# Altium schematic sheet Sonic.SchDoc
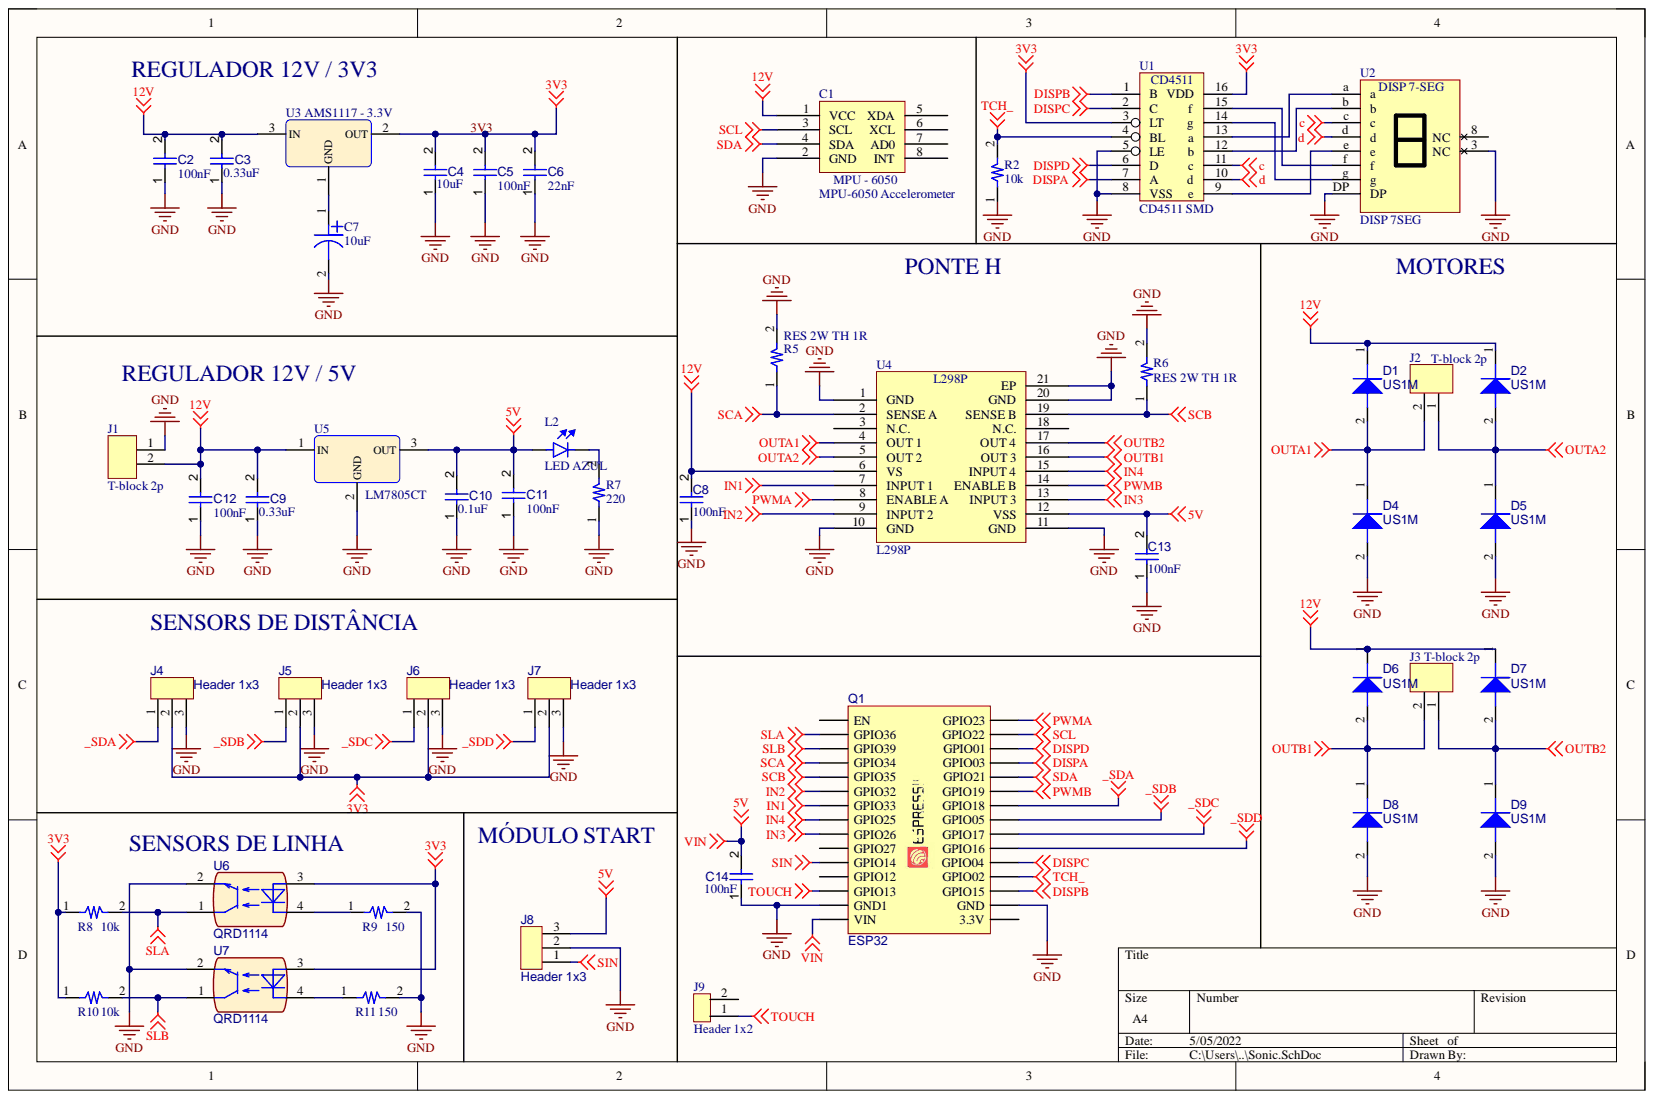
[diagram p0: Sonic.SchDoc]

## Parts
C1  MPU-6050 Accelerometer  [MPU-6050 Accelerometer-Footprint-1]
C2  value 100nF  [CAPC2013X95X50LL10T25]  (hidden comment: Capacitor 100 nF +/-10% 50 V 0805)
C3  value 0.33uF  [CAPC2013X95X50LL10T25]  (hidden comment: Capacitor 100 nF +/-10% 50 V 0805)
C4  value 10uF  [CAPC2013X95X50LL10T25]  (hidden comment: Capacitor 100 nF +/-10% 50 V 0805)
C5  value 100nF  [CAPC2013X95X50LL10T25]  (hidden comment: Capacitor 100 nF +/-10% 50 V 0805)
C6  value 22nF  [CAPC2013X95X50LL10T25]  (hidden comment: Capacitor 100 nF +/-10% 50 V 0805)
C7  10uF  [ElerolitcCap 35V]
C8  value 100nF  [CAPC2013X95X50LL10T25]  (hidden comment: Capacitor 100 nF +/-10% 50 V 0805)
C9  value 0.33uF  [CAPC2013X95X50LL10T25]  (hidden comment: Capacitor 100 nF +/-10% 50 V 0805)
C10  value 0.1uF  [CAPC2013X95X50LL10T25]  (hidden comment: Capacitor 100 nF +/-10% 50 V 0805)
C11  value 100nF  [CAPC2013X95X50LL10T25]  (hidden comment: Capacitor 100 nF +/-10% 50 V 0805)
C12  value 100nF  [CAPC2013X95X50LL10T25]  (hidden comment: Capacitor 100 nF +/-10% 50 V 0805)
C13  value 100nF  [CAPC2013X95X50LL10T25]  (hidden comment: Capacitor 100 nF +/-10% 50 V 0805)
C14  value 100nF  [CAPC2013X95X50LL10T25]  (hidden comment: Capacitor 100 nF +/-10% 50 V 0805)
D1  US1M  [DO-214AC]
D2  US1M  [DO-214AC]
D4  US1M  [DO-214AC]
D5  US1M  [DO-214AC]
D6  US1M  [DO-214AC]
D7  US1M  [DO-214AC]
D8  US1M  [DO-214AC]
D9  US1M  [DO-214AC]
J1  T-block 2p  [T-block 2p]
J2  T-block 2p  [T-block 2p]
J3  T-block 2p  [T-block 2p]
J4  Header 1x3  [Header 1x3]
J5  Header 1x3  [Header 1x3]
J6  Header 1x3  [Header 1x3]
J7  Header 1x3  [Header 1x3]
J8  Header 1x3  [Header 1x3]
J9  Header 1x2  [Header 1x2]
L2  LED AZUL  [LED 5mm-Footprint-1]
Q1  ESP32  [DOTI ESP32]
R2  10k  [47K RES SMD]
R5  RES 2W TH 1R  [RES 2W TH]
R6  RES 2W TH 1R  [RES 2W TH]
R7  220  [47K RES SMD]
R8  10k  [47K RES SMD]
R9  150  [47K RES SMD]
R10  10k  [47K RES SMD]
R11  150  [47K RES SMD]
U1  CD4511 SMD  [CD4511 SMD]
U2  DISP 7SEG  [DISP 7SEG]
U3  AMS1117 - 3.3V  [AMS1117]
U4  L298P  [L298P]
U5  LM7805CT  [L78XX FAMILY]
U6  QRD1114  [QRD1114]
U7  QRD1114  [QRD1114]

## Nets
5V: C10.2 C11.2 C13.2 C14.2 J8.3 L2.1 Q1.1(VIN) U4.12(VSS) U5.3
12V: C1.1(VCC) C2.2 C3.2 C8.2 C9.2 C12.2 D1.1 D2.1 D6.1 D7.1 J1.2 U3.3 U4.6(VS) U5.1
_SDA: J4.1 Q1.22(GPIO18)
_SDB: J5.1 Q1.23(GPIO05)
_SDC: J6.1 Q1.24(GPIO17)
_SDD: J7.1 Q1.25(GPIO16)
c: U1.11(c) U2.c
d: U1.10(d) U2.d
DISPA: Q1.19(GPIO03) U1.7(A)
DISPB: Q1.28(GPIO15) U1.1(B)
DISPC: Q1.26(GPIO04) U1.2(C)
DISPD: Q1.18(GPIO01) U1.6(D)
GND: C1.2(GND) C2.1 C3.1 C4.1 C5.1 C6.1 C7.2 C8.1 C9.1 C10.1 C11.1 C12.1 C13.1 C14.1 D4.2 D5.2 D8.2 D9.2 J1.1 J4.3 J5.3 J6.3 J7.3 J8.2 Q1.2(GND1) Q1.29(GND) R2.1 R5.2 R6.2 R7.1 R9.2 R11.2 U1.5(LE) U1.8(VSS) U2.3(NC) U2.DP U4.1(GND) U4.10(GND) U4.11(GND) U4.20(GND) U4.21(EP) U5.2 U6.2 U7.2
IN1: Q1.9(GPIO33) U4.7(INPUT_1)
IN2: Q1.10(GPIO32) U4.9(INPUT_2)
IN3: Q1.7(GPIO26) U4.13(INPUT_3)
IN4: Q1.8(GPIO25) U4.15(INPUT_4)
OUTA1: D1.2 D4.1 J2.2 U4.4(OUT_1)
OUTA2: D2.2 D5.1 J2.1 U4.5(OUT_2)
OUTB1: D6.2 D8.1 J3.2 U4.16(OUT_3)
OUTB2: D7.2 D9.1 J3.1 U4.17(OUT_4)
PWMA: Q1.16(GPIO23) U4.8(ENABLE_A)
PWMB: Q1.21(GPIO19) U4.14(ENABLE_B)
SCA: Q1.12(GPIO34) R5.1 U4.2(SENSE_A)
SCB: Q1.11(GPIO35) R6.1 U4.19(SENSE_B)
SCL: C1.3(SCL) Q1.17(GPIO22)
SDA: C1.4(SDA) Q1.20(GPIO21)
SIN: J8.1 Q1.5(GPIO14)
SLA: Q1.14(GPIO36) R8.2 U6.1
SLB: Q1.13(GPIO39) R10.2 U7.1
TCH_: Q1.27(GPIO02) R2.2 U1.4(BL)
TOUCH: J9.1 Q1.3(GPIO13)
3V3: C4.2 C5.2 C6.2 J4.2 J5.2 J6.2 J7.2 R8.1 R10.1 U1.3(LT) U1.16(VDD) U3.2 U6.3 U7.3
NetC7_1: C7.1 U3.1
NetL2_2: L2.2 R7.2
NetR9_1: R9.1 U6.4
NetR11_1: R11.1 U7.4
NetU1_9: U1.9(e) U2.e
NetU1_12: U1.12(b) U2.b
NetU1_13: U1.13(a) U2.a
NetU1_14: U1.14(g) U2.g
NetU1_15: U1.15(f) U2.f

Not connected: C1 5(XDA) 6(XCL) 7(AD0) 8(INT); J9 2; Q1 4(GPIO12) 6(GPIO27) 15(EN) 30(3.3V); U2 8(NC); U4 3(N.C.) 18(N.C.)

## Library data of the parts
C1 = CMP-00002-1: Accelerometer, Gyroscope, 3 axis sensor; Component Kind: Standard; Library Name: Sistema_Elevador_ElevaUV.IntLib
C2-C6 C8-C14 = CMP-001-00018-5: Chip Capacitor, 100 nF, +/- 10%, 50 V, 0805 (2012 Metric); Capacitor Type: Ceramic; Voltage Rating: 50V; Case/Package: 0805; Component Kind: Standard; Max Operating Temperature: 125°C; Min Operating Temperature: -55°C; Mounting Technology: SM; Pins: 2; RoHS Compliant: True; Tolerance: 10%; Library Name: Universidade Estadual Paulista - Unesp
C7 = 10uF SMD Eletrolitc Cap: CAP ALUM 10UF 20% 35V SMD; Manufacturer: Panasonic; Manufacturer Part Number: EEEFK1V100UR; ESR (Equivalent Series Resistance): 1.35R; Leakage Current: 3uA; Life (Hours): 2000Hour; Material: Aluminium; Polarity: Polar; Ripple Current: 90mA; Capacitance: 10uF; Case/Package: Radial; Category: Aluminum Electrolytic Capacitors; Component Kind: Standard; ComponentLink1Description: https://datasheet.ciiva.com/11777/0900766b80e4e8b0-11777251.pdf?src-supplier=RSComponents; ComponentLink1URL: https://datasheet.ciiva.com/11777/0900766b80e4e8b0-11777251.pdf?src-supplier=RSComponents; ComponentLink2Description: https://datasheet.ciiva.com/29313/443474-da-01-en-smd-elko-vfk-b-10-uf-35v-29313572.pdf?src-supplier=Conrad; ComponentLink2URL: https://datasheet.ciiva.com/29313/443474-da-01-en-smd-elko-vfk-b-10-uf-35v-29313572.pdf?src-supplier=Conrad; ComponentLink3Description: https://datasheet.ciiva.com/3773/41790907s9016630n1386-3773674.pdf?src-supplier=Arrow+Electronics; ComponentLink3URL: https://datasheet.ciiva.com/3773/41790907s9016630n1386-3773674.pdf?src-supplier=Arrow+Electronics; ComponentLink4Description: https://datasheet.ciiva.com/11673/aba0000ce120-11673880.pdf?src-supplier=Avnet; ComponentLink4URL: https://datasheet.ciiva.com/11673/aba0000ce120-11673880.pdf?src-supplier=Avnet; ComponentLink5Description: https://datasheet.ciiva.com/2983/aba0000ce120-2983969.pdf?src-supplier=Avnet; ComponentLink5URL: https://datasheet.ciiva.com/2983/aba0000ce120-2983969.pdf?src-supplier=Avnet; ComponentLink6Description: https://datasheet.ciiva.com/43463/58258-43463543.pdf?src-supplier=Farnell; ComponentLink6URL: https://datasheet.ciiva.com/43463/58258-43463543.pdf?src-supplier=Farnell; ComponentLink7Description: https://datasheet.ciiva.com/43485/1676921-43485502.pdf?src-supplier=Farnell; ComponentLink7URL: https://datasheet.ciiva.com/43485/1676921-43485502.pdf?src-supplier=Farnell; ComponentLink8Description: https://datasheet.ciiva.com/30131/aba0000ce120-30131022.pdf?src-supplier=Onlinecomponents.com; ComponentLink8URL: https://datasheet.ciiva.com/30131/aba0000ce120-30131022.pdf?src-supplier=Onlinecomponents.com; ComponentLink9Description: https://datasheet.ciiva.com/48524/fk-eng-tds-48524122.pdf?src-supplier=Distrelec; ComponentLink9URL: https://datasheet.ciiva.com/48524/fk-eng-tds-48524122.pdf?src-supplier=Distrelec; ComponentLink10Description: https://datasheet.ciiva.com/46437/1676921-46437695.pdf?src-supplier=Element14; ComponentLink10URL: https://datasheet.ciiva.com/46437/1676921-46437695.pdf?src-supplier=Element14; ComponentLink11Description: https://datasheet.ciiva.com/17356/aba0000ce120-17356246.pdf?src-supplier=Avnet+Europe; ComponentLink11URL: https://datasheet.ciiva.com/17356/aba0000ce120-17356246.pdf?src-supplier=Avnet+Europe; Construction: Cylindrical; Contact Plating: Tin; Diameter: 4mm; Height: 5.8mm; Height - Seated (Max): 0.24inch; Impedance: 1.35R; Lead Free: Lead Free; Length: 5.8mm; Max Operating Temperature: 105°C; Min Operating Temperature: -55°C; Mount: Surface Mount,PCB; Number of Pins: 2; Package Quantity: 1; Packaging: Tape and Reel; Pricing 1: 1=0,35000, 10=0,24000, 50=0,16820, 100=0,14420, 500=0,10816, 1000=0,09133 (USD); Radiation Hardening: No; REACH SVHC: No SVHC; Ripple Current (AC): 90mA; RoHS: Yes; RoHS Compliant: Yes; Series: FK; Stock 1: 7253; Supplier 1: Digi-Key; Supplier Part Number 1: PCE3834CT-ND; Termination: SMD/SMT; Tolerance: 20%; Voltage: 35V; Voltage Rating: 35V; Voltage Rating (DC): 35V; Width: 5.5mm; Library Name: Extrusora_Schlib.SchLib
D1 D2 D4-D9 = US1M: Rectifier Diode, 1 Element, 1A, 1000V V(RRM), Silicon, DO-214AC; Manufacturer: ON Semiconductor / Fairchild; Manufacturer Part Number: S1M; Capacitance: 12pF; Element Configuration: Single; Forward Voltage: 1V; Max Forward Surge Current (Ifsm): 30A; Max Repetitive Reverse Voltage (Vrrm): 1kV; Max Reverse Current: 1uA; Max Surge Current: 40A; Polarity: Standard; Power Dissipation: 1.4W; Recovery Time: 1800ns; Reverse Recovery Time: 1.8us; Average Rectified Current: 1A; Case/Package: DO-214AC; Category: Rectifier Diodes; Component Kind: Standard; ComponentLink1Description: https://datasheet.ciiva.com/4452/s1m-191340-4452980.pdf?src-supplier=Mouser; ComponentLink1URL: https://datasheet.ciiva.com/4452/s1m-191340-4452980.pdf?src-supplier=Mouser; ComponentLink2Description: https://datasheet.ciiva.com/27051/1072719-27051364.pdf?src-supplier=Jameco; ComponentLink2URL: https://datasheet.ciiva.com/27051/1072719-27051364.pdf?src-supplier=Jameco; ComponentLink3Description: https://datasheet.ciiva.com/910/s1a-910496.pdf?src-supplier=Digi-Key; ComponentLink3URL: https://datasheet.ciiva.com/910/s1a-910496.pdf?src-supplier=Digi-Key; ComponentLink4Description: https://datasheet.ciiva.com/5739/1766308-5739339.pdf?src-supplier=Farnell; ComponentLink4URL: https://datasheet.ciiva.com/5739/1766308-5739339.pdf?src-supplier=Farnell; ComponentLink5Description: https://datasheet.ciiva.com/8303/s1k-8303577.pdf?src-supplier=Avnet; ComponentLink5URL: https://datasheet.ciiva.com/8303/s1k-8303577.pdf?src-supplier=Avnet; ComponentLink6Description: https://datasheet.ciiva.com/7965/1766308-7965189.pdf?src-supplier=Element14; ComponentLink6URL: https://datasheet.ciiva.com/7965/1766308-7965189.pdf?src-supplier=Element14; ComponentLink7Description: https://datasheet.ciiva.com/7965/96437-7965306.pdf?src-supplier=Element14; ComponentLink7URL: https://datasheet.ciiva.com/7965/96437-7965306.pdf?src-supplier=Element14; ComponentLink8Description: https://datasheet.ciiva.com/7965/1766308-7965307.pdf?src-supplier=Element14; ComponentLink8URL: https://datasheet.ciiva.com/7965/1766308-7965307.pdf?src-supplier=Element14; ComponentLink9Description: https://datasheet.ciiva.com/22651/1766308-22651974.pdf?src-supplier=Element14; ComponentLink9URL: https://datasheet.ciiva.com/22651/1766308-22651974.pdf?src-supplier=Element14; Contact Plating: Tin,Matte; Current: 1A; Current Rating: 1A; Forward Current: 1A; Height: 2.2mm; Lead Free: Lead Free; Length: 4.75mm; Max Operating Temperature: 150°C; Max Reverse Voltage (DC): 1kV; Min Operating Temperature: -55°C; Mount: Surface Mount; Number of Pins: 2; Output Current: 1A; Package Quantity: 1; Packaging: Tape and Reel; Peak Non-Repetitive Surge Current: 30A; Pricing 1: 1=0,44000, 10=0,32400, 100=0,18340, 500=0,12148, 1000=0,09313, 2000=0,08099 (USD); Radiation Hardening: No; REACH SVHC: No SVHC; Reverse Voltage: 1kV; RoHS: No; RoHS Compliant: No; Stock 1: 1057473; Supplier 1: Digi-Key; Supplier Part Number 1: S1MFSCT-ND; Termination: SMD/SMT; Voltage: 1kV; Voltage Rating (DC): 1kV; Width: 5.28mm; Library Name: Extrusora_Schlib.SchLib
J1-J3 = T-block 2p: WURTH ELEKTRONIK - 691213710002 - Wire-To-Board Terminal Block, 2, 300 V, 10 A, 26 AWG, 16 AWG, 1.5 mm RoHS Compliant: Yes; Manufacturer: Wurth Electronics; Current: 10A; Number of Contacts: 2; Number of Levels: 1; Pitch: 0.197inch; Plating: Brass,Steel; Stripping Length: -7mm; Voltage: 300V; Wire Gauge (Max): 26AWG; Wire Gauge (Min): 16AWG; Category: Terminal Blocks; Color: Green; Component Kind: Standard; ComponentLink1Description: https://datasheet.ciiva.com/824/6912137100xx-824076.pdf?src-supplier=Digi-Key; ComponentLink1URL: https://datasheet.ciiva.com/824/6912137100xx-824076.pdf?src-supplier=Digi-Key; ComponentLink2Description: https://datasheet.ciiva.com/48693/001088616-da-01-en-wr-tbl-terminal-block213-691213710002-48693191.pdf?src-supplier=Conrad; ComponentLink2URL: https://datasheet.ciiva.com/48693/001088616-da-01-en-wr-tbl-terminal-block213-691213710002-48693191.pdf?src-supplier=Conrad; Contact Material: Brass; Contact Plating: Brass,Tin; Current Rating: 10A; Height: 10mm; Housing Material: PA66; Length: 8.3mm; Manufacturer Part Number: 691213710002; Max Operating Temperature: 105°C; Min Operating Temperature: -40°C; Mount: Through Hole; Number of Positions Per Level: 2; Package Quantity: 650; Packaging: Bulk; Pricing 1: 1=0,96000, 10=0,86200, 50=0,74760 (USD); REACH SVHC: Unknown; RoHS: Yes; RoHS Compliant: Yes; Series: WR-TBL Series 213; Stock 1: 1369; Supplier 1: Digi-Key; Termination: Screw; Voltage Rating: 300V; Width: 10.6mm; Wire/Cable Gauge: 26AWG; Library Name: Extrusora_Schlib.SchLib
J4-J8 = Header 1x3: Low Profile Tiger Eye™ Header Strips, 2.00 mm Pitch; Manufacturer: Samtec; Manufacturer Part Number: TMM-103-03-S-S; Gender: Male; Max Current Rating: 3.9A; Max Voltage Rating (AC): 500V; Number of Contacts: 3; Pitch: 2mm; Category: Headers and Wire Housings; Component Kind: Standard; ComponentLink1Description: http://components.arrow.com/part/dataview/2689490S8167872N1004?src-supplier=Arrow+Electronics; ComponentLink1URL: http://components.arrow.com/part/dataview/2689490S8167872N1004?src-supplier=Arrow+Electronics; ComponentLink2Description: http://www.onlinecomponents.com/datasheet/tmm-103-03-s-s.aspx?p=40278396&src-supplier=Onlinecomponents.com; ComponentLink2URL: http://www.onlinecomponents.com/datasheet/tmm-103-03-s-s.aspx?p=40278396&src-supplier=Onlinecomponents.com; ComponentLink3Description: http://components.arrow.com/part/dataview/0323_01319670?src-supplier=Arrow+Europe; ComponentLink3URL: http://components.arrow.com/part/dataview/0323_01319670?src-supplier=Arrow+Europe; ComponentLink4Description: ftp://ftp.samtec.com/webfiles/PDF/TMM_TH.PDF?src-supplier=Avnet; ComponentLink4URL: ftp://ftp.samtec.com/webfiles/PDF/TMM_TH.PDF?src-supplier=Avnet; ComponentLink5Description: http://suddendocs.samtec.com/prints/tmm-1xx-xx-xx-x-xx-xxx-mkt1.pdf?src-supplier=Digi-Key; ComponentLink5URL: http://suddendocs.samtec.com/prints/tmm-1xx-xx-xx-x-xx-xxx-mkt1.pdf?src-supplier=Digi-Key; ComponentLink6Description: ftp://ftp.samtec.com/webfiles/PDF/TMM_TH.PDF?src-supplier=Avnet+Europe; ComponentLink6URL: ftp://ftp.samtec.com/webfiles/PDF/TMM_TH.PDF?src-supplier=Avnet+Europe; ComponentLink7Description: http://www.farnell.com/datasheets/2600218.pdf?src-supplier=Newark; ComponentLink7URL: http://www.farnell.com/datasheets/2600218.pdf?src-supplier=Newark; Contact Material: Bronze; Contact Plating: Gold; Depth: 1.97mm; Housing Color: Black; Housing Material: Polymer; Lead Free: Lead Free; Length: 6mm; Max Operating Temperature: 125°C; Min Operating Temperature: -55°C; Mount: Through Hole; Number of Rows: 1; Orientation: Straight; Packaging: Bulk; Pricing 1: 1=1,10000, 10=0,92600, 100=0,83160, 500=0,68040, 1000=0,60480, 5000=0,52920, 10000=0,50400 (USD); Radiation Hardening: No; RoHS: Yes; RoHS Compliant: Yes; Stock 1: 390; Supplier 1: Digi-Key; Supplier Part Number 1: SAM10198-ND; Termination: Solder; Library Name: Extrusora_Schlib.SchLib
J9 = Header 1x2: Conn Socket Strip SKT 2 POS Solder ST Thru-Hole Automotive Gang of Tubes; Manufacturer: TE Connectivity; Manufacturer Part Number: 534206-1; Approvals: CSA; Boss: No (Without); Connector Type: Receptacle,Connector,Socket; Contact Current Rating: 2A; Contact Post Length: 2.92mm; Contact Resistance: 12MR; Gender: Female; High Temperature Compatible: No; Insulation Resistance: 5GR; Number of Contacts: 2; PCB Mount Retention: Without; PCB Mounting Orientation: Vertical; PCB Thickness: 1.57mm; Pitch: 2.54mm; Row Spacing: 0.1inch; Stackable: Yes; Category: Board to Board Connectors; Color: Black; Component Kind: Standard; ComponentLink1Description: https://datasheet.ciiva.com/743/eng-cd-534206-r7-743683.pdf?src-supplier=Digi-Key; ComponentLink1URL: https://datasheet.ciiva.com/743/eng-cd-534206-r7-743683.pdf?src-supplier=Digi-Key; ComponentLink2Description: https://datasheet.ciiva.com/9527/eng-ds-1307819-ampmodu-0811-9527722.pdf?src-supplier=Avnet; ComponentLink2URL: https://datasheet.ciiva.com/9527/eng-ds-1307819-ampmodu-0811-9527722.pdf?src-supplier=Avnet; ComponentLink3Description: https://datasheet.ciiva.com/30088/eng-cat-1307819-0306-084-222-30088134.pdf?src-supplier=Onlinecomponents.com; ComponentLink3URL: https://datasheet.ciiva.com/30088/eng-cat-1307819-0306-084-222-30088134.pdf?src-supplier=Onlinecomponents.com; Contact Material: Bronze; Contact Plating: Gold,Tin,Lead; Contact Shape: Square; Contact Type: Female Socket; Current Rating: 2A; Depth: 5.03mm; ELV: Non-Compliant; Flammability Rating: UL94 V-0; Height: 5.03mm; Housing Color: Black; Housing Material: Polyester; Lead Free: Contains Lead; Length: 2.54mm; Max Operating Temperature: 105°C; Min Operating Temperature: -65°C; Mount: Through Hole; Number of Positions: 2; Number of Rows: 2; Orientation: Straight; Package Quantity: 22; Packaging: Rail/Tube; Pricing 1: 1=1,64000, 10=1,43100, 220=1,27891, 440=1,06575, 1100=0,91350, 5060=0,81200 (USD); Radiation Hardening: No; RoHS: Yes; RoHS Compliant: No; Sealable: No (Without); Series: AMPMODU MOD II; Stock 1: 3390; Supplier 1: Digi-Key; Supplier Part Number 1: A26451-ND; Tail Length: 2.92mm; Termination: Solder; Library Name: Extrusora_Schlib.SchLib
L2 = CMP-00006-2: LED 5mm; Component Kind: Standard; Library Name: Sistema_Elevador_ElevaUV.SCHLIB
Q1 = ESP32: Microcontrolador ESP 32; Component Kind: Standard; Library Name: Extrusora_Schlib.SchLib
R2 R7-R11 = CMP-007-000007-1: Res Thick Film 1206 47K Ohm 5% 1/4W 200ppm/C Molded SMD SMD Punched Carrier T/R; Manufacturer: Panasonic; Manufacturer Part Number: ERJ-8GEYJ473V; Max Power Dissipation: 250mW; Resistance: 47kR; Temperature Coefficient: 200ppm/°C; Voltage Rating: 200V; Case Code (Imperial): 1206; Case Code (Metric): 3216; Case/Package: 1206; Category: Chip SMD Resistors; Component Kind: Standard; ComponentLink1Description: https://datasheet.ciiva.com/6138/1500035-6138237.pdf?src-supplier=Farnell; ComponentLink1URL: https://datasheet.ciiva.com/6138/1500035-6138237.pdf?src-supplier=Farnell; ComponentLink2Description: https://datasheet.ciiva.com/43460/1500035-43460253.pdf?src-supplier=Farnell; ComponentLink2URL: https://datasheet.ciiva.com/43460/1500035-43460253.pdf?src-supplier=Farnell; ComponentLink3Description: https://datasheet.ciiva.com/9189/aoa0000ce1-9189353.pdf?src-supplier=Avnet; ComponentLink3URL: https://datasheet.ciiva.com/9189/aoa0000ce1-9189353.pdf?src-supplier=Avnet; ComponentLink4Description: https://datasheet.ciiva.com/16772/70255313-16772315.pdf?src-supplier=Allied+Electronics; ComponentLink4URL: https://datasheet.ciiva.com/16772/70255313-16772315.pdf?src-supplier=Allied+Electronics; ComponentLink5Description: https://datasheet.ciiva.com/28587/1678796-28587311.pdf?src-supplier=Element14; ComponentLink5URL: https://datasheet.ciiva.com/28587/1678796-28587311.pdf?src-supplier=Element14; ComponentLink6Description: https://datasheet.ciiva.com/46389/1500035-46389605.pdf?src-supplier=Element14; ComponentLink6URL: https://datasheet.ciiva.com/46389/1500035-46389605.pdf?src-supplier=Element14; ComponentLink7Description: https://datasheet.ciiva.com/46377/1500035-46377621.pdf?src-supplier=Element14; ComponentLink7URL: https://datasheet.ciiva.com/46377/1500035-46377621.pdf?src-supplier=Element14; ComponentLink8Description: https://datasheet.ciiva.com/17209/aoa0000ce1-17209711.pdf?src-supplier=Avnet+Europe; ComponentLink8URL: https://datasheet.ciiva.com/17209/aoa0000ce1-17209711.pdf?src-supplier=Avnet+Europe; Construction: Rectangular; Contact Plating: Tin; Depth: 1.6mm; Design Item ID: 47K RES SMD; Features: Automotive AEC-Q200; Height: 0.028inch; Lead Free: Lead Free; Length: 3.2mm; Max Operating Temperature: 155°C; Military Standard: Not; Min Operating Temperature: -55°C; Mount: Surface Mount; Number of Terminations: 2; Package Quantity: 1; Packaging: Tape and Reel; Power Rating: 250mW; Pricing 1: 1=0,10000, 10=0,06800, 100=0,02780, 1000=0,01249, 2500=0,01084 (USD); Radiation Hardening: No; REACH SVHC: No SVHC; Resistance Tolerance: 5%; Resistor Type: Thick Film; RoHS: Yes; RoHS Compliant: Yes; Series: ERJ; Stock 1: 22656; Termination: SMD/SMT; Tolerance: 5%; Voltage Rating (DC): 200V; Width: 0.063inch; Library Name: Universidade Estadual Paulista - Unesp; Source: Extrusora_Schlib.SchLib
R5 R6 = RES 2W TH: Res High Power Wirewound 1 Ohm 5% 2W ±300ppm/°C Conformal Axial T/R; Manufacturer: Yageo; Manufacturer Part Number: PNP200JR-52-1R; Case/Package: Axial; Category: Through-Hole Resistors; Component Kind: Standard; ComponentLink1Description: http://www.yageo.com/documents/recent/Yageo%20LR_PNP_2013.pdf?src-supplier=Digi-Key; ComponentLink1URL: http://www.yageo.com/documents/recent/Yageo%20LR_PNP_2013.pdf?src-supplier=Digi-Key; ComponentLink2Description: https://datasheet.octopart.com/PNP200JR-52-1R-Yageo-datasheet-155367624.pdf?src-supplier=Future+Electronics; ComponentLink2URL: https://datasheet.octopart.com/PNP200JR-52-1R-Yageo-datasheet-155367624.pdf?src-supplier=Future+Electronics; Features: Flame Retardant Coating; Length: 0.354inch; Max Operating Temperature: 200°C; Max Power Dissipation: 2W; Min Operating Temperature: -40°C; Number of Terminations: 2; Package Quantity: 2500; Packaging: Tape and Reel; Pricing 1: 1=0,44000, 10=0,33900, 25=0,25800, 50=0,19160, 100=0,14250, 250=0,12040, 500=0,10322, 1000=0,07864 (USD); Resistance: 1R; Resistor Type: Wirewound; RoHS Compliant: Yes; Series: PNP; Stock 1: 4840; Supplier 1: Digi-Key; Supplier Part Number 1: 13-PNP200JR-52-1RCT-ND; Tolerance: 5%; Library Name: Extrusora_Schlib.SchLib
U1 = CD4511 SMD: Latch/Decoder/Driver Single 4-to-7 16-Pin PDIP Tube / IC 7-SEG LED DECOD/DRVR 16-DIP; Manufacturer: Texas Instruments; Manufacturer Part Number: CD4511BE; Input Capacitance: 5pF; Logic Function: Decoder,Latch,Inverter; Low Level Output Current: 4.2mA; Max Supply Voltage: 18V; Min Supply Voltage: 3V; Number of Channels: 4; Number of Circuits: 1; Number of Output Lines: 7; Operating Supply Current: 40nA; Operating Supply Voltage: 3.3V; Polarity: Non-Inverting; Power Dissipation: 500mW; Propagation Delay: 1320ns; Quiescent Current: 100uA; Case/Package: PDIP; Category: Logic ICs; Component Kind: Standard; ComponentLink1Description: https://datasheet.ciiva.com/14134/cd4511b-eng-tds-14134539.pdf?src-supplier=Distrelec; ComponentLink1URL: https://datasheet.ciiva.com/14134/cd4511b-eng-tds-14134539.pdf?src-supplier=Distrelec; ComponentLink2Description: https://datasheet.ciiva.com/23923/1676966-23923187.pdf?src-supplier=Newark; ComponentLink2URL: https://datasheet.ciiva.com/23923/1676966-23923187.pdf?src-supplier=Newark; ComponentLink3Description: https://datasheet.ciiva.com/4669/schs072b-127055-4669008.pdf?src-supplier=Mouser; ComponentLink3URL: https://datasheet.ciiva.com/4669/schs072b-127055-4669008.pdf?src-supplier=Mouser; ComponentLink4Description: https://datasheet.ciiva.com/19456/895377-19456856.pdf?src-supplier=Jameco; ComponentLink4URL: https://datasheet.ciiva.com/19456/895377-19456856.pdf?src-supplier=Jameco; ComponentLink5Description: https://datasheet.ciiva.com/15092/getdatasheetpartid-40986-15092104.pdf?src-supplier=Verical; ComponentLink5URL: https://datasheet.ciiva.com/15092/getdatasheetpartid-40986-15092104.pdf?src-supplier=Verical; ComponentLink6Description: https://datasheet.ciiva.com/29297/172669-da-01-en-c-mos-ic-cd-4511-be-dip16-tid-29297144.pdf?src-supplier=Conrad; ComponentLink6URL: https://datasheet.ciiva.com/29297/172669-da-01-en-c-mos-ic-cd-4511-be-dip16-tid-29297144.pdf?src-supplier=Conrad; ComponentLink7Description: https://datasheet.ciiva.com/1940/cd4511b-1940497.pdf?src-supplier=Digi-Key; ComponentLink7URL: https://datasheet.ciiva.com/1940/cd4511b-1940497.pdf?src-supplier=Digi-Key; ComponentLink8Description: https://datasheet.ciiva.com/3304/cd4511b-3304861.pdf?src-supplier=Avnet; ComponentLink8URL: https://datasheet.ciiva.com/3304/cd4511b-3304861.pdf?src-supplier=Avnet; ComponentLink9Description: https://datasheet.ciiva.com/26228/cd4511b-26228711.pdf?src-supplier=Avnet+Europe; ComponentLink9URL: https://datasheet.ciiva.com/26228/cd4511b-26228711.pdf?src-supplier=Avnet+Europe; ComponentLink10Description: https://datasheet.ciiva.com/41457/001013538-da-01-en-ic-7-seg-led-decod-cd4511be-pdip-16-tid-41457275.pdf?src-supplier=Conrad; ComponentLink10URL: https://datasheet.ciiva.com/41457/001013538-da-01-en-ic-7-seg-led-decod-cd4511be-pdip-16-tid-41457275.pdf?src-supplier=Conrad; Contact Plating: Gold; Height: 4.57mm; Lead Free: Lead Free; Length: 19.3mm; Max Operating Temperature: 125°C; Min Operating Temperature: -55°C; Mount: Through Hole; Number of Elements: 1; Number of Input Lines: 4; Number of Inputs: 4; Number of Outputs: 7; Number of Pins: 16; Package Quantity: 25; Packaging: Rail/Tube; Pricing 1: 1=0,74000, 10=0,64700, 25=0,60800, 100=0,49610, 250=0,46084, 500=0,39220, 1000=0,32375 (USD); Radiation Hardening: No; REACH SVHC: No SVHC; RoHS: Yes; Stock 1: 1217; Supplier 1: Digi-Key; Supplier Part Number 1: 296-2072-ND; Turn-On Delay Time: 1320ns; Weight: 0.03357oz; Width: 6.35mm; Library Name: Extrusora_Schlib.SchLib
U2 = DISP 7SEG: Led Display, Thru-hole; Display Height, .56IN.; Red; 625NM; 6400MCD; 10MA; N/a Degrees; Manufacturer: Kingbright; Manufacturer Part Number: SC56-11EWA; Display Type: Segment; Element Configuration: Common Cathode; Forward Current: 20mA; Forward Voltage: 2V; Luminous Intensity: 6.4mcd; Max Power Dissipation: 75mW; Number of Characters: 1; Case/Package: DIP; Category: LED Displays; Color: Red; Component Kind: Standard; ComponentLink1Description: https://datasheet.ciiva.com/2103/sc56-11ewa-2103800.pdf?src-supplier=Digi-Key; ComponentLink1URL: https://datasheet.ciiva.com/2103/sc56-11ewa-2103800.pdf?src-supplier=Digi-Key; ComponentLink2Description: https://datasheet.ciiva.com/4421/sc56-11ewa-55277-4421794.pdf?src-supplier=Mouser; ComponentLink2URL: https://datasheet.ciiva.com/4421/sc56-11ewa-55277-4421794.pdf?src-supplier=Mouser; ComponentLink3Description: https://datasheet.ciiva.com/16485/sc56-11ewa-16485247.pdf?src-supplier=Future+Electronics; ComponentLink3URL: https://datasheet.ciiva.com/16485/sc56-11ewa-16485247.pdf?src-supplier=Future+Electronics; ComponentLink4Description: https://datasheet.ciiva.com/1317/57087-1317666.pdf?src-supplier=Newark; ComponentLink4URL: https://datasheet.ciiva.com/1317/57087-1317666.pdf?src-supplier=Newark; ComponentLink5Description: https://datasheet.ciiva.com/20164/57-0120e-20164993.pdf?src-supplier=Rapid+Electronics; ComponentLink5URL: https://datasheet.ciiva.com/20164/57-0120e-20164993.pdf?src-supplier=Rapid+Electronics; ComponentLink6Description: https://datasheet.ciiva.com/29335/160116-da-01-en-anzeige-zi-hoehe-14mm-typ-sc56-11ewa-29335328.pdf?src-supplier=Conrad; ComponentLink6URL: https://datasheet.ciiva.com/29335/160116-da-01-en-anzeige-zi-hoehe-14mm-typ-sc56-11ewa-29335328.pdf?src-supplier=Conrad; ComponentLink7Description: https://datasheet.ciiva.com/8036/1864138-8036269.pdf?src-supplier=Element14; ComponentLink7URL: https://datasheet.ciiva.com/8036/1864138-8036269.pdf?src-supplier=Element14; Height: 0.75inch; Illumination Color: Red; Lead Free: Lead Free; Max Operating Temperature: 85°C; Min Operating Temperature: -40°C; Package Quantity: 40; Packaging: Rail/Tube; Power Consumption: 75mW; Pricing 1: 1=2,05000, 10=1,36500, 100=1,05070, 500=0,94976, 1000=0,84000 (USD); REACH SVHC: No SVHC; RoHS: Yes; RoHS Compliant: Yes; Stock 1: 11393; Supplier 1: Digi-Key; Supplier Part Number 1: 754-1465-5-ND; Test Current: 20mA; Wavelength: 625nm; Width: 0.5inch; Library Name: Extrusora_Schlib.SchLib
U3 = AMS1117 - 1.5V: Regulador de tensão ams1117; Component Kind: Standard; Library Name: Extrusora_Schlib.SchLib
U4 = L298P: Dual Full Bridge Motor Driver 5 Volt 22-Pin (20+2Tab) PowerSO Tube; Manufacturer: STMicroelectronics; Collector Emitter Voltage (VCEO): 46V; Max Collector Current: 3A; Min Supply Voltage: 4.8V; Motor Type: Brushed; Number of Channels: 2; Number of Outputs: 4; Operating Supply Current: 13mA; Operating Supply Voltage: 42V; Output Current: 2A; Case/Package: SOIC; Category: Motor Drivers; Component Kind: Standard; ComponentLink1Description: https://datasheet.ciiva.com/4895/cd00000240-224711-4895923.pdf?src-supplier=Mouser; ComponentLink1URL: https://datasheet.ciiva.com/4895/cd00000240-224711-4895923.pdf?src-supplier=Mouser; ComponentLink2Description: https://datasheet.ciiva.com/19456/1389265-19456706.pdf?src-supplier=Jameco; ComponentLink2URL: https://datasheet.ciiva.com/19456/1389265-19456706.pdf?src-supplier=Jameco; ComponentLink3Description: https://datasheet.ciiva.com/15109/getdatasheetpartid-162293-15109403.pdf?src-supplier=Verical; ComponentLink3URL: https://datasheet.ciiva.com/15109/getdatasheetpartid-162293-15109403.pdf?src-supplier=Verical; ComponentLink4Description: https://datasheet.ciiva.com/26880/l298p-26880155.pdf?src-supplier=IIIC; ComponentLink4URL: https://datasheet.ciiva.com/26880/l298p-26880155.pdf?src-supplier=IIIC; ComponentLink5Description: https://datasheet.ciiva.com/1957/cd00000240-1957024.pdf?src-supplier=Digi-Key; ComponentLink5URL: https://datasheet.ciiva.com/1957/cd00000240-1957024.pdf?src-supplier=Digi-Key; Height: 3.3mm; Lead Free: Lead Free; Length: 16mm; Manufacturer Part Number: L298P; Max Operating Temperature: 130°C; Max Output Current: 3A; Max Supply Voltage: 46V; Min Operating Temperature: -25°C; Mount: Surface Mount; Number of Pins: 20; Output Current per Channel: 2A; Package Quantity: 31; Packaging: Rail/Tube; Pricing 1: 1=7,96000, 10=7,19000, 25=6,85560, 100=5,95270, 250=5,68512, 500=5,52108 (USD); Radiation Hardening: No; RoHS: Yes; RoHS Compliant: Yes; Series: -; Stock 1: 3; Supplier 1: Digi-Key; Width: 11.1mm; Library Name: Extrusora_Schlib.SchLib
U5 = LM7805CT: Series 3-Terminal Positive Regulators; Manufacturer: Texas Instruments; Manufacturer Part Number: LM7805CT/NOPB; Accuracy: 2%; Dropout Voltage: 2V; Max Input Voltage: 35V; Max Output Current: 1A; Min Input Voltage: 10V; Number of Outputs: 1; Output Type: Fixed; Output Voltage: 5V; Quiescent Current: 8mA; Case/Package: TO-220; Category: Voltage Regulators - Linear; Component Kind: Standard; ComponentLink1Description: https://datasheet.ciiva.com/14280/lm340-n-14280911.pdf?src-supplier=Avnet; ComponentLink1URL: https://datasheet.ciiva.com/14280/lm340-n-14280911.pdf?src-supplier=Avnet; ComponentLink2Description: https://datasheet.ciiva.com/15083/lm7805c-15083790.pdf?src-supplier=Digi-Key; ComponentLink2URL: https://datasheet.ciiva.com/15083/lm7805c-15083790.pdf?src-supplier=Digi-Key; ComponentLink3Description: https://datasheet.ciiva.com/45987/902071-45987836.pdf?src-supplier=Jameco; ComponentLink3URL: https://datasheet.ciiva.com/45987/902071-45987836.pdf?src-supplier=Jameco; Height: 8.89mm; Lead Free: Contains Lead; Length: 10.16mm; Max Operating Temperature: 125°C; Min Operating Temperature: 0°C; Mount: Through Hole; Number of Pins: 3; Number of Regulators: 1; Output Current: 1A; Output Voltage Accuracy: 2%; Package Quantity: 45; Packaging: Rail/Tube; Polarity: Positive; Pricing 1: 1=1,63000, 10=1,46300, 25=1,38080, 100=1,17630, 250=1,10452, 500=0,96646, 1000=0,87007 (USD); Radiation Hardening: No; RoHS: Yes; RoHS Compliant: Yes; Series: -; Stock 1: 11933; Supplier 1: Digi-Key; Supplier Part Number 1: 296-47192-ND; Width: 4.7mm; Library Name: Extrusora_Schlib.SchLib
U6 U7 = QRD1114: QRD1114 Series 30 V 50 mA Phototransistor Reflective Object Sensor; Manufacturer: ON Semiconductor / Fairchild; Collector Emitter Breakdown Voltage: 30V; Fall Time: 50us; Forward Current: 50mA; Forward Voltage: 1.7V; Input Current: 20mA; Max Collector Current: 1mA; Max Power Dissipation: 100mW; Number of Channels: 1; Reverse Breakdown Voltage: 5V; Rise Time: 10us; Sensing Distance: 1.27mm; Wavelength: 940nm; Category: Optocouplers; Collector Emitter Voltage (VCEO): 30V; Collector-emitter Voltage-Max: 30V; Component Kind: Standard; ComponentLink1Description: https://datasheet.ciiva.com/12069/qrd1114-192054-12069087.pdf?src-supplier=Mouser; ComponentLink1URL: https://datasheet.ciiva.com/12069/qrd1114-192054-12069087.pdf?src-supplier=Mouser; ComponentLink2Description: https://datasheet.ciiva.com/19453/874939-19453556.pdf?src-supplier=Jameco; ComponentLink2URL: https://datasheet.ciiva.com/19453/874939-19453556.pdf?src-supplier=Jameco; ComponentLink3Description: https://datasheet.ciiva.com/3305/qrd1113-3305733.pdf?src-supplier=Avnet; ComponentLink3URL: https://datasheet.ciiva.com/3305/qrd1113-3305733.pdf?src-supplier=Avnet; ComponentLink4Description: https://datasheet.ciiva.com/2823/qrd1113-2823879.pdf?src-supplier=Digi-Key; ComponentLink4URL: https://datasheet.ciiva.com/2823/qrd1113-2823879.pdf?src-supplier=Digi-Key; ComponentLink5Description: https://datasheet.ciiva.com/26165/qrd1113-26165833.pdf?src-supplier=Avnet+Europe; ComponentLink5URL: https://datasheet.ciiva.com/26165/qrd1113-26165833.pdf?src-supplier=Avnet+Europe; ComponentLink6Description: https://datasheet.ciiva.com/17088/qrd1113-17088344.pdf?src-supplier=Future+Electronics; ComponentLink6URL: https://datasheet.ciiva.com/17088/qrd1113-17088344.pdf?src-supplier=Future+Electronics; Contact Plating: Tin,Matte; Height: 4.65mm; Lead Free: Lead Free; Length: 6.1mm; Manufacturer Part Number: QRD1114; Max Operating Temperature: 85°C; Min Operating Temperature: -40°C; Mount: Through Hole; Number of Elements: 1; Number of Pins: 4; Operating Supply Voltage: 1.7V; Output Type: Phototransistor; Package Quantity: 100; Packaging: Bulk; Power Dissipation: 100mW; Pricing 1: 1=1,97000, 5=1,86000, 10=1,59400, 25=1,27560, 50=1,16920, 100=1,06300, 500=0,93012, 1000=0,82382, 5000=0,79725 (USD); Radiation Hardening: No; REACH SVHC: No SVHC; Response Time: 50us; Reverse Voltage (DC): 5V; RoHS: Yes; RoHS Compliant: Yes; Series: QRD1114; Stock 1: 8862; Supplier 1: Digi-Key; Supplier Part Number 1: QRD1114-ND; Weight: 0g; Width: 4.39mm; Library Name: Extrusora_Schlib.SchLib
